# Altium schematic sheet DAC5672.SchDoc(DAC5672)
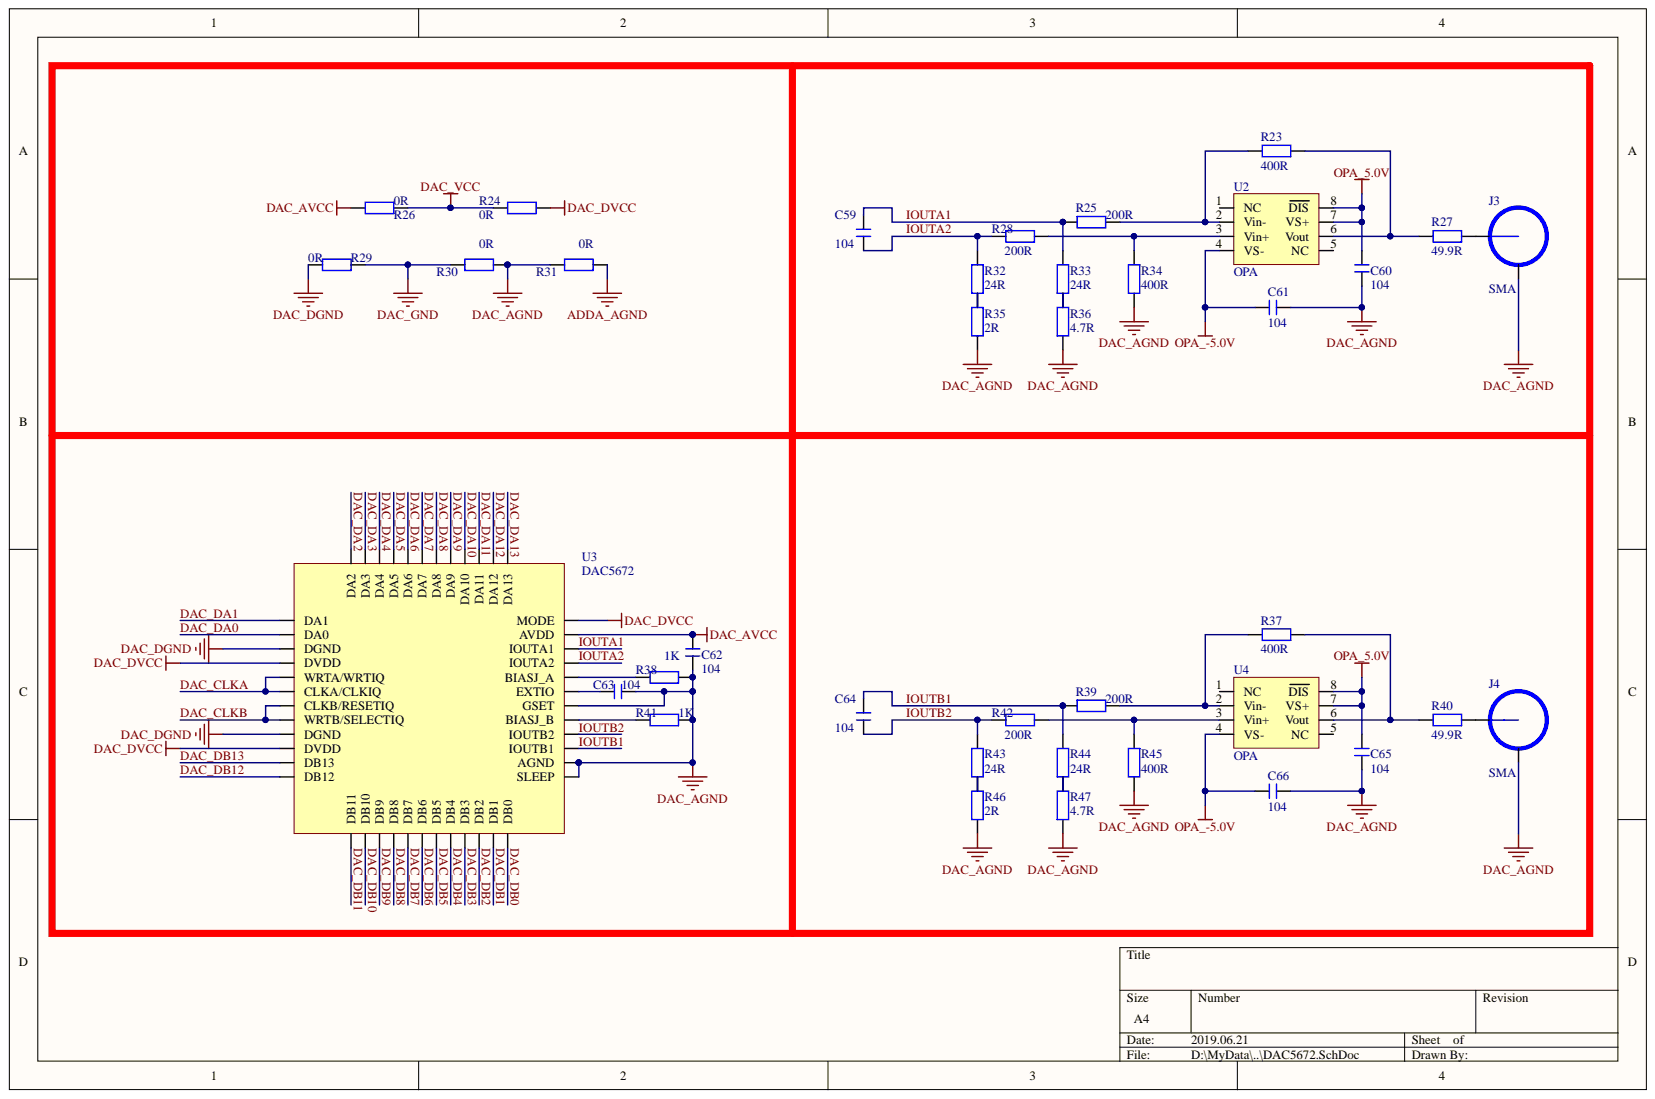
[diagram p0: DAC5672.SchDoc]

## Parts
C59  104  [C 0805_L]
C60  104  [C 0805_L]
C61  104  [C 0805_L]
C62  104  [C 0805_L]
C63  104  [C 0805_L]
C64  104  [C 0805_L]
C65  104  [C 0805_L]
C66  104  [C 0805_L]
J3  SMA
J4  SMA
R23  400R  [R 0805_L]
R24  0R  [R 0805_L]
R25  200R  [R 0805_L]
R26  0R  [R 0805_L]
R27  49.9R  [R 0805_L]
R28  200R  [R 0805_L]
R29  0R  [R 0805_L]
R30  0R  [R 0805_L]
R31  0R  [R 0805_L]
R32  24R  [R 0805_L]
R33  24R  [R 0805_L]
R34  400R  [R 0805_L]
R35  2R  [R 0805_L]
R36  4.7R  [R 0805_L]
R37  400R  [R 0805_L]
R38  1K  [R 0805_L]
R39  200R  [R 0805_L]
R40  49.9R  [R 0805_L]
R41  1K  [R 0805_L]
R42  200R  [R 0805_L]
R43  24R  [R 0805_L]
R44  24R  [R 0805_L]
R45  400R  [R 0805_L]
R46  2R  [R 0805_L]
R47  4.7R  [R 0805_L]
U2  OPA
U3  DAC5672  [QFN48 7X7_L]
U4  OPA

## Nets
ADDA_AGND: R31.2
DAC_AGND: C60.1 C61.1 C62.1 C63.2 C65.1 C66.1 J3.2 J4.2 R30.2 R31.1 R34.1 R35.1 R36.2 R38.2 R41.2 R45.1 R46.1 R47.2 U3.37(SLEEP) U3.38(AGND) U3.42(GSET)
DAC_AVCC: C62.2 R26.1 U3.47(AVDD)
DAC_DGND: R29.1 U3.15(DGND) U3.21(DGND)
DAC_DVCC: R24.2 U3.16(DVDD) U3.22(DVDD) U3.48(MODE)
DAC_GND: R29.2 R30.1
DAC_VCC: R24.1 R26.2
OPA_5.0V: C60.2 C65.2 U2.7(VS+) U2.8(DIS) U4.7(VS+) U4.8(DIS)
OPA_-5.0V: C61.2 C66.2 U2.4(VS-) U4.4(VS-)
DAC_CLKA: U3.17(WRTA/WRTIQ) U3.18(CLKA/CLKIQ)
DAC_CLKB: U3.19(CLKB/RESETIQ) U3.20(WRTB/SELECTIQ)
DAC_DA0: U3.14(DA0)
DAC_DA1: U3.13(DA1)
DAC_DA2: U3.12(DA2)
DAC_DA3: U3.11(DA3)
DAC_DA4: U3.10(DA4)
DAC_DA5: U3.9(DA5)
DAC_DA6: U3.8(DA6)
DAC_DA7: U3.7(DA7)
DAC_DA8: U3.6(DA8)
DAC_DA9: U3.5(DA9)
DAC_DA10: U3.4(DA10)
DAC_DA11: U3.3(DA11)
DAC_DA12: U3.2(DA12)
DAC_DA13: U3.1(DA13)
DAC_DB0: U3.36(DB0)
DAC_DB1: U3.35(DB1)
DAC_DB2: U3.34(DB2)
DAC_DB3: U3.33(DB3)
DAC_DB4: U3.32(DB4)
DAC_DB5: U3.31(DB5)
DAC_DB6: U3.30(DB6)
DAC_DB7: U3.29(DB7)
DAC_DB8: U3.28(DB8)
DAC_DB9: U3.27(DB9)
DAC_DB10: U3.26(DB10)
DAC_DB11: U3.25(DB11)
DAC_DB12: U3.24(DB12)
DAC_DB13: U3.23(DB13)
IOUTA1: C59.1 R25.2 R33.1 U3.46(IOUTA1)
IOUTA2: C59.2 R28.2 R32.2 U3.45(IOUTA2)
IOUTB1: C64.1 R39.2 R44.1 U3.39(IOUTB1)
IOUTB2: C64.2 R42.2 R43.2 U3.40(IOUTB2)
NetC63_1: C63.1 U3.43(EXTIO)
NetJ3_1: J3.1 R27.2
NetJ4_1: J4.1 R40.2
NetR23_1: R23.1 R25.1 U2.2(Vin-)
NetR23_2: R23.2 R27.1 U2.6(Vout)
NetR28_1: R28.1 R34.2 U2.3(Vin+)
NetR32_1: R32.1 R35.2
NetR33_2: R33.2 R36.1
NetR37_1: R37.1 R39.1 U4.2(Vin-)
NetR37_2: R37.2 R40.1 U4.6(Vout)
NetR38_1: R38.1 U3.44(BIASJ_A)
NetR41_1: R41.1 U3.41(BIASJ_B)
NetR42_1: R42.1 R45.2 U4.3(Vin+)
NetR43_1: R43.1 R46.2
NetR44_2: R44.2 R47.1

Not connected: U2 1(NC) 5(NC); U4 1(NC) 5(NC)

## Library data of the parts
C59-C66 = C: 无极性贴片电容; 版权归属: 源创客; BOM名称: 无极性贴片电容; Component Kind: Standard; Library Name: 3.1   - 电阻-电容-电感.SchLib; 学习资源: www.ooocke.com
R23-R47 = R: 贴片电阻; 版权归属: 源创客; BOM名称: 贴片电阻; Component Kind: Standard; Library Name: 3.1   - 电阻-电容-电感.SchLib; 学习资源: www.ooocke.com
U2 U4 = 单运放: Component Kind: Standard; Library Name: 电子竞赛.SchLib
J3 J4 = SMA: Component Kind: Standard; Library Name: 电子竞赛.SchLib
U3 = DAC5672: Component Kind: Standard; Library Name: 电子竞赛.SchLib
